# Altium schematic sheet spilcd.SchDoc
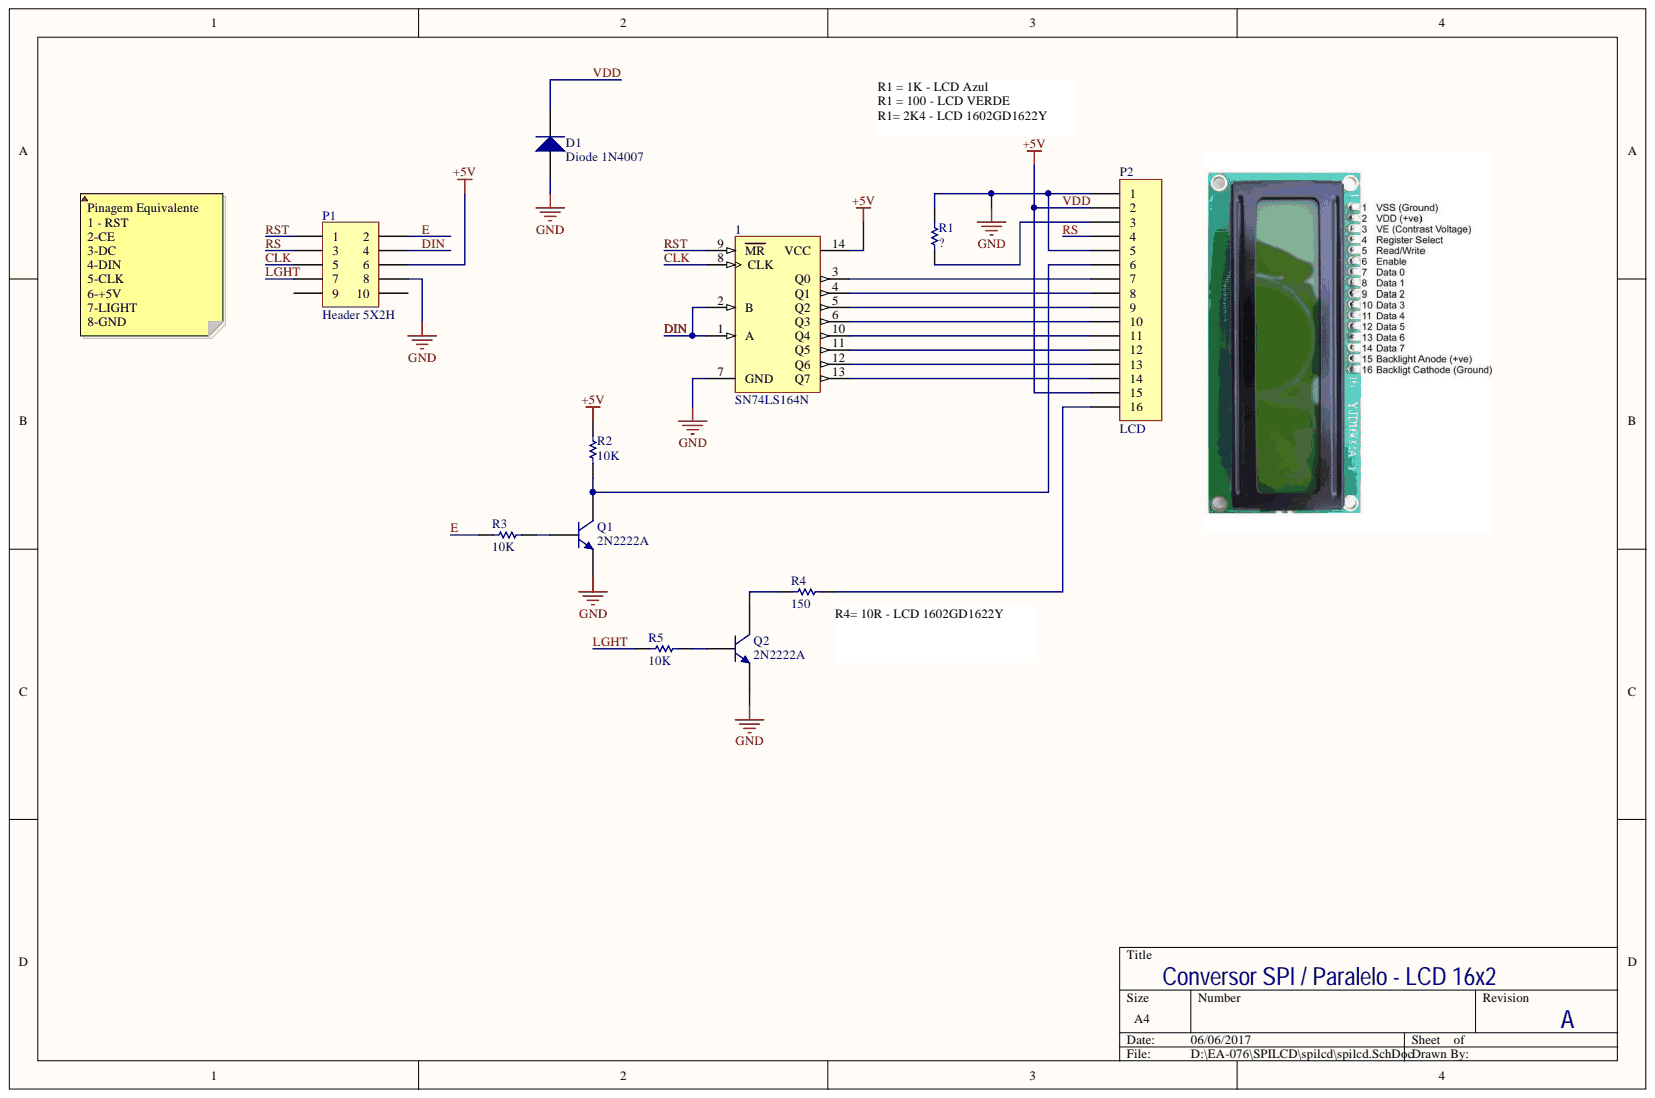
[diagram p0: spilcd.SchDoc]

## Parts
1  SN74LS164N  [DIP14-3D]
D1  Diode 1N4007  [DO-41]
P1  Header 5X2H  [HDR2X5H]
P2  LCD  [HDR1X16]
Q1  2N2222A  [TO-18]
Q2  2N2222A  [TO-18]
R1  Contraste  [Axial 0.4 3D]  (value not drawn)
R2  value 10K  [Axial 0.4 3D]  (hidden comment: Contraste)
R3  value 10K  [Axial 0.4 3D]  (hidden comment: Contraste)
R4  value 150  [Axial 0.4 3D]  (hidden comment: Contraste)
R5  value 10K  [Axial 0.4 3D]  (hidden comment: Contraste)

## Nets
GND: 1.7(GND) D1.1 P1.8 P2.1 P2.5 Q1.3 Q2.3 R1.2
CLK: 1.8(CLK) P1.5
DIN: 1.1(A) 1.2(B) P1.4
E: P1.2 R3.2
LGHT: P1.7 R5.2
RS: P1.3 P2.4
RST: 1.9(MR) P1.1
VDD: 1.14(VCC) D1.2 P1.6 P2.2 P2.15 R2.2
Net1_3: 1.3(Q0) P2.7
Net1_4: 1.4(Q1) P2.8
Net1_5: 1.5(Q2) P2.9
Net1_6: 1.6(Q3) P2.10
Net1_10: 1.10(Q4) P2.11
Net1_11: 1.11(Q5) P2.12
Net1_12: 1.12(Q6) P2.13
Net1_13: 1.13(Q7) P2.14
NetP2_3: P2.3 R1.1
NetP2_6: P2.6 Q1.2 R2.1
NetP2_16: P2.16 R4.1
NetQ1_1: Q1.1 R3.1
NetQ2_1: Q2.1 R5.1
NetQ2_2: Q2.2 R4.2

Not connected: P1 9 10

## Library data of the parts
1 = SN74LS164N: Serial-In Parallel-Out Shift Register; Component Kind: Standard; ComponentLink1Description: Manufacturer Link; ComponentLink1URL: http://www.onsemi.com/; ComponentLink2Description: Datasheet; ComponentLink2URL: http://www.onsemi.com/pub/Collateral/SN74LS164-D.PDF; DatasheetVersion: Dec-1999; Library Name: ON Semi Logic Register.IntLib; PackageDescription: DIP; 14 Leads; Row Spacing 7.62 mm; Pitch 2.54 mm; PackageReference: 646-06; PackageVersion: 1995; Published: 15-Apr-2002; Signal Integrity: SN74LS259N; Publisher: Altium Limited
D1 = Diode 1N4007: 1 Amp General Purpose Rectifier; Code_JEDEC: DO-204-AL; Component Kind: Standard; ComponentLink1Description: Manufacturer Link; ComponentLink1URL: http://www.fairchildsemi.com/; ComponentLink2Description: Datasheet; ComponentLink2URL: http://www.fairchildsemi.com/ds/1N%2F1N4001.pdf; DatasheetVersion: 1998; LatestRevisionDate: 17-Jul-2002; LatestRevisionNote: Re-released for DXP Platform.; Library Name: Miscellaneous Devices.IntLib; PackageDescription: Diode, Axial; Body 5.2 x 2.7 mm (LxDia. max), Lead Dia. 0.86 mm (max); PackageReference: DO-41; PackageVersion: Aug-1999; Published: 8-Jun-2000; Signal Integrity: 1N4007; Simulation: 1N4007; Publisher: Altium Limited
P1 = Header 5X2H: Header, 5-Pin, Dual row, Right Angle; Component Kind: Standard; LatestRevisionDate: 17-Jul-2002; LatestRevisionNote: Re-released for DXP Platform.; Library Name: Miscellaneous Connectors.IntLib; Signal Integrity: Connector; Publisher: Altium Limited
P2 = Header 16: Header, 16-Pin; Component Kind: Standard; LatestRevisionDate: 17-Jul-2002; LatestRevisionNote: Re-released for DXP Platform.; Library Name: Miscellaneous Connectors.IntLib; Signal Integrity: Connector; Publisher: Altium Limited
Q1 Q2 = 2N2222A: High Speed Switches; Component Kind: Standard; ComponentLink1Description: Manufacturer Link; ComponentLink1URL: http://www.st.com/; ComponentLink2Description: Datasheet; ComponentLink2URL: http://www.st.com/stonline/products/literature/ds/9288/2n2219a.pdf; DatasheetVersion: Jun-1999; LatestRevisionDate: 11-Dec-2002; LatestRevisionNote: Sim Port Map correction; Library Name: ST Discrete BJT.IntLib; PackageDescription: Can; 3 Leads; Pin Circle Dia. 2.54 mm; Lead Dia. 0.49 mm (max); PackageReference: TO-18; Published: 8-Jun-2000; Signal Integrity: 2N2222A; Simulation: 2N2222A; Publisher: Altium Limited
R1-R5 = Res1: Resistor; Component Kind: Standard; LatestRevisionDate: 17-Jul-2002; LatestRevisionNote: Re-released for DXP Platform.; Library Name: Miscellaneous Devices.IntLib; PackageDescription: Axial Device, Thru-Hole; 2 Leads; 0.3 in Pin Spacing; PackageReference: AXIAL-0.3; Published: 8-Jun-2000; Signal Integrity: Res; Simulation: RESISTOR; Publisher: Altium Limited
  R1: Value: ?
